# Altium schematic sheet AD8367_AGC.SchDoc
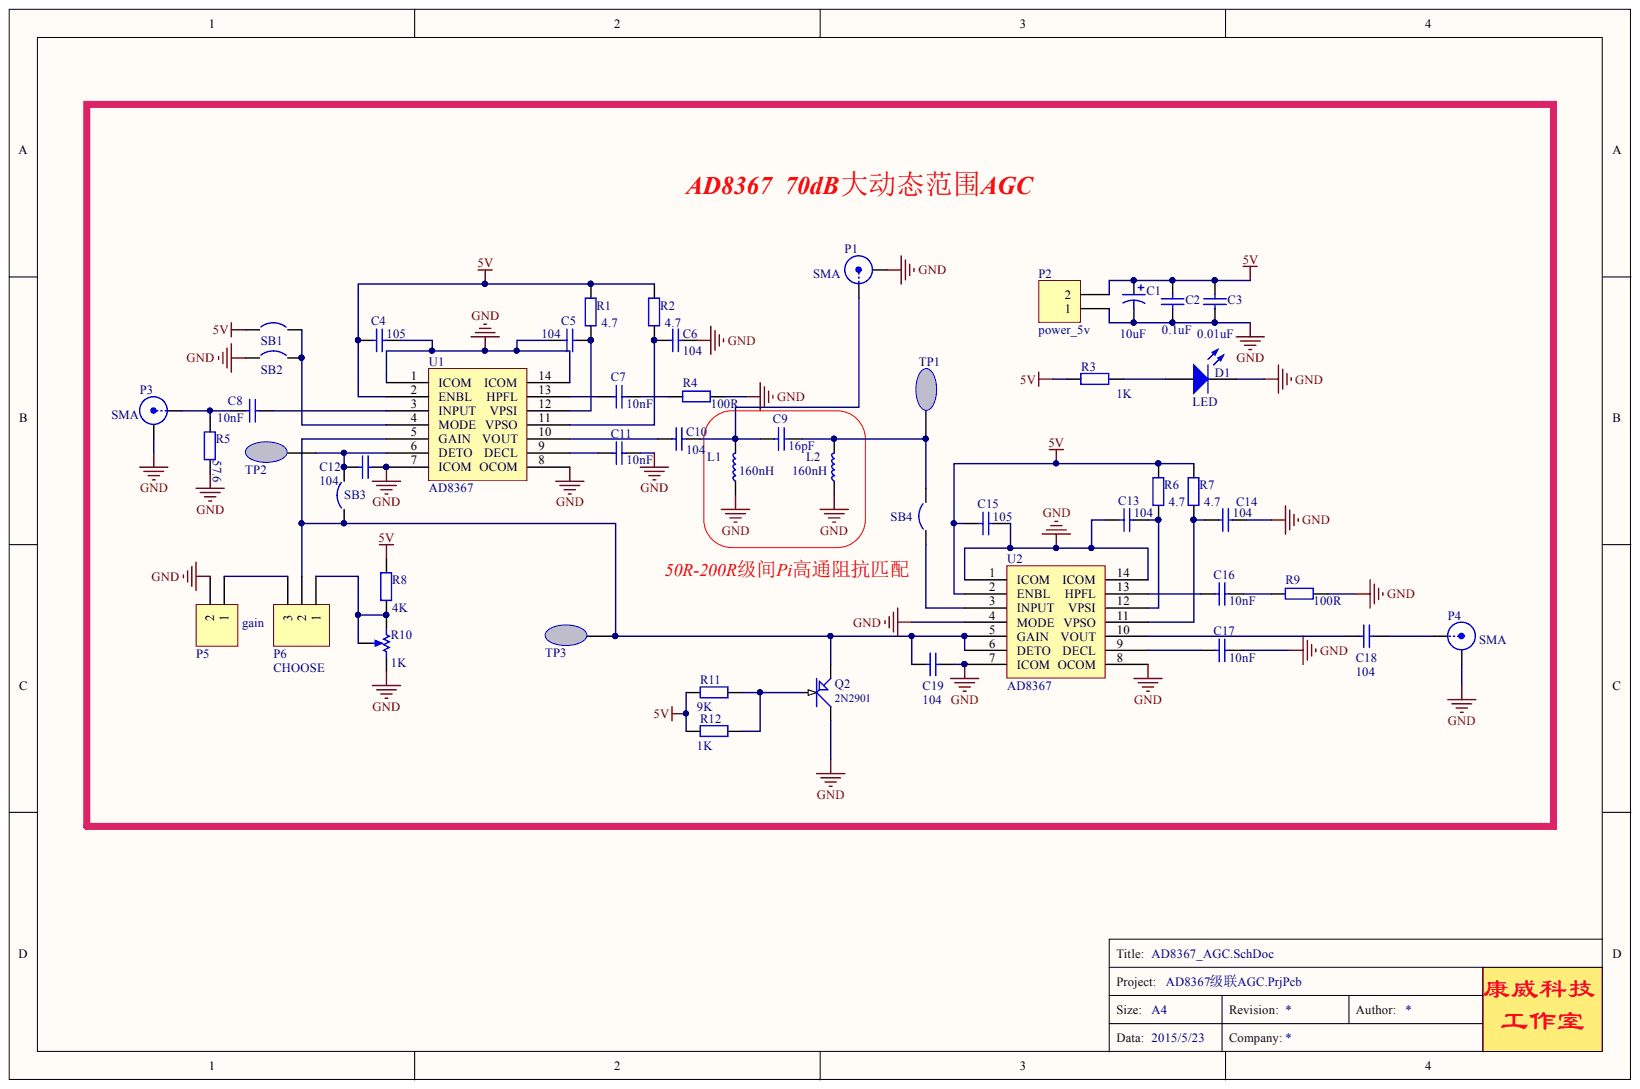
[diagram p0: AD8367_AGC.SchDoc]

## Parts
C1  value 10uF  [1812]
C2  value 0.1uF  [1812]
C3  value 0.01uF  [0805-cap]
C4  value 105  [0805-cap]
C5  value 104  [0805-cap]
C6  value 104  [0805-cap]
C7  value 10nF  [0805-cap]
C8  value 10nF  [0805-cap]
C9  value 16pF  [0805-cap]
C10  value 104  [0805-cap]
C11  value 10nF  [0805-cap]
C12  value 104  [0805-cap]
C13  value 104  [0805-cap]
C14  value 104  [0805-cap]
C15  value 105  [0805-cap]
C16  value 10nF  [0805-cap]
C17  value 10nF  [0805-cap]
C18  value 104  [0805-cap]
C19  value 104  [0805-cap]
D1  LED  [0805-led]
L1  160nH  [0805_L]
L2  160nH  [0805_L]
P1  SMA  [SM]
P2  power_5v  [接头x2]
P3  SMA  [SM]
P4  SMA  [SM]
P5  gain  [SIP2]
P6  CHOOSE  [SIP3]
Q2  2N2901  [NPN]
R1  value 4.7  [0805_r]
R2  value 4.7  [0805_r]
R3  value 1K  [0805_r]
R4  value 100R  [0805_r]
R5  value 57.6  [0805_r]
R6  value 4.7  [0805_r]
R7  value 4.7  [0805_r]
R8  value 4K  [0805_r]
R9  value 100R  [0805_r]
R10  value 1K  [精密电位器]
R11  value 9K  [0805_r]
R12  value 1K  [0805_r]
SB1  Circuit Breaker  [CONECT]  (value not drawn)
SB2  Circuit Breaker  [CONECT]  (value not drawn)
SB3  Circuit Breaker  [CONECT]  (value not drawn)
SB4  Circuit Breaker  [CONECT]  (value not drawn)
TP1  TEST  [TEST]  (value not drawn)
TP2  TEST  [TEST]  (value not drawn)
TP3  TEST  [TEST]  (value not drawn)
U1  AD8367  [AD8367]
U2  AD8367  [AD8367]

## Nets
5V: C1.1 C2.2 C3.2 C4.2 C15.2 P2.2 R1.1 R2.1 R3.1 R6.1 R7.1 R8.2 R11.2 R12.2 SB1.1 U1.2(ENBL) U2.2(ENBL)
GND: C1.2 C2.1 C3.1 C4.1 C5.1 C6.2 C11.1 C12.2 C13.2 C14.1 C15.1 C17.1 C19.2 D1.2 L1.1 L2.1 P1.2 P2.1 P3.2 P4.2 P5.2 Q2.3 R4.2 R5.1 R9.2 R10.2 SB2.1 U1.1(ICOM) U1.7(ICOM) U1.8(OCOM) U1.14(ICOM) U2.1(ICOM) U2.4(MODE) U2.7(ICOM) U2.8(OCOM) U2.14(ICOM)
NetC5_2: C5.2 R1.2 U1.12(VPSI)
NetC6_1: C6.1 R2.2 U1.11(VPSO)
NetC7_1: C7.1 R4.1
NetC7_2: C7.2 U1.13(HPFL)
NetC8_1: C8.1 U1.3(INPUT)
NetC8_2: C8.2 P3.1 R5.2
NetC9_1: C9.1 L2.2 SB4.2 TP1.1
NetC9_2: C9.2 C10.2 L1.2 P1.1
NetC10_1: C10.1 U1.10(VOUT)
NetC11_2: C11.2 U1.9(DECL)
NetC12_1: C12.1 SB3.2 TP2.1 U1.6(DETO)
NetC13_1: C13.1 R6.2 U2.12(VPSI)
NetC14_2: C14.2 R7.2 U2.11(VPSO)
NetC16_1: C16.1 R9.1
NetC16_2: C16.2 U2.13(HPFL)
NetC17_2: C17.2 U2.9(DECL)
NetC18_1: C18.1 U2.10(VOUT)
NetC18_2: C18.2 P4.1
NetC19_1: C19.1 P6.2 Q2.1 SB3.1 TP3.1 U1.5(GAIN) U2.5(GAIN) U2.6(DETO)
NetD1_1: D1.1 R3.2
NetP5_1: P5.1 P6.3
NetP6_1: P6.1 R8.1 R10.1 R10.3
NetQ2_2: Q2.2 R11.1 R12.1
NetSB1_2: SB1.2 SB2.2 U1.4(MODE)
NetSB4_1: SB4.1 U2.3(INPUT)
AD8367  70DB大动态范围AGC:

## Library data of the parts
C1 = Cap Pol1: Polarized Capacitor (Radial); Component Kind: Standard; LatestRevisionDate: 17-Jul-2002; LatestRevisionNote: Re-released for DXP Platform.; Library Name: Miscellaneous Devices.IntLib; PackageDescription: Polarized Capacitor (Radial); 2 Leads; PackageReference: RB7.6-15; Published: 8-Jun-2000; Signal Integrity: Cap Pol; Simulation: CAP; Publisher: Altium Limited
C2-C19 = Cap: Capacitor; Component Kind: Standard; LatestRevisionDate: 17-Jul-2002; LatestRevisionNote: Re-released for DXP Platform.; Library Name: Miscellaneous Devices.IntLib; PackageDescription: Radial Cap, Thru-Hole; 2 Leads; 0.3 in Pin Spacing; PackageReference: RAD-0.3; Published: 8-Jun-2000; Signal Integrity: Cap; Simulation: CAP; Publisher: Altium Limited
L1 L2 = CZ: Component Kind: Standard; Library Name: MySCHlib.SchLib
P1 P3 P4 = BNC: BNC Elbow Connector; Component Kind: Standard; LatestRevisionDate: 17-Jul-2002; LatestRevisionNote: Re-released for DXP Platform.; Library Name: Miscellaneous Devices.SchLib; Signal Integrity: Connector; Publisher: Altium Limited
P2 P5 = Header 2: Header, 2-Pin; Component Kind: Standard; LatestRevisionDate: 17-Jul-2002; LatestRevisionNote: Re-released for DXP Platform.; Signal Integrity: Connector; Library Name: Xiaowei.SchLib; Publisher: Altium Limited
P6 = Header 3: Header, 3-Pin; Component Kind: Standard; LatestRevisionDate: 17-Jul-2002; LatestRevisionNote: Re-released for DXP Platform.; Signal Integrity: Connector; Library Name: Xiaowei.SchLib; Publisher: Altium Limited
Q2 = PNP1: PNP Transistor; Component Kind: Standard
R1 R3-R9 R11 R12 = Res2: Resistor; Component Kind: Standard; LatestRevisionDate: 17-Jul-2002; LatestRevisionNote: Re-released for DXP Platform.; Library Name: Miscellaneous Devices.IntLib; PackageDescription: Axial Device, Thru-Hole; 2 Leads; 0.4 in Pin Spacing; PackageReference: AXIAL-0.4; Published: 8-Jun-2000; Signal Integrity: Res; Simulation: RESISTOR; Publisher: Altium Limited
R2 = Res2: Resistor; Value: 4.7; Component Kind: Standard; LatestRevisionDate: 17-Jul-2002; LatestRevisionNote: Re-released for DXP Platform.; Library Name: Miscellaneous Devices.IntLib; PackageDescription: Axial Device, Thru-Hole; 2 Leads; 0.4 in Pin Spacing; PackageReference: AXIAL-0.4; Published: 8-Jun-2000; Signal Integrity: Res; Simulation: RESISTOR; Publisher: Altium Limited
R10 = RPot: Potentiometer; Set Position: 0.5; Sim Note: Enter the Resistance value and the set point into parameter fields.; Component Kind: Standard; LatestRevisionDate: 17-Jul-2002; LatestRevisionNote: Re-released for DXP Platform.; Library Name: Miscellaneous Devices.IntLib; PackageDescription: Variable Resistor, Thru-Hole; 3 Leads; PackageReference: VR5; Published: 8-Jun-2000; Simulation: POT; Publisher: Altium Limited
SB1-SB4 = Circuit Breaker: Solder: NO; Component Kind: Standard; Description: Circuit Breaker; Library Name: MCD_TOOLS.SCHLIB; Published: 24-Mar-1999; Revision: July-2002: Re-released for DXP Platform.; Publisher: Altium Limited
TP1-TP3 = 测试口: Component Kind: Standard; Library Name: Xiaowei.SchLib
D1 = LED: Component Kind: Standard; LatestRevisionDate: 08-Jul-2005; LatestRevisionNote: Mech Layer 1 removed.; PackageDescription: LED; 2 Leads; PackageReference: LED-0; Published: 8-Jun-2000; Library Name: Xiaowei.SchLib; Publisher: Altium Limited
U1 U2 = AD8367: Component Kind: Standard; Library Name: Xiaowei.SchLib
